# Altium schematic sheet Schematics.SchDoc
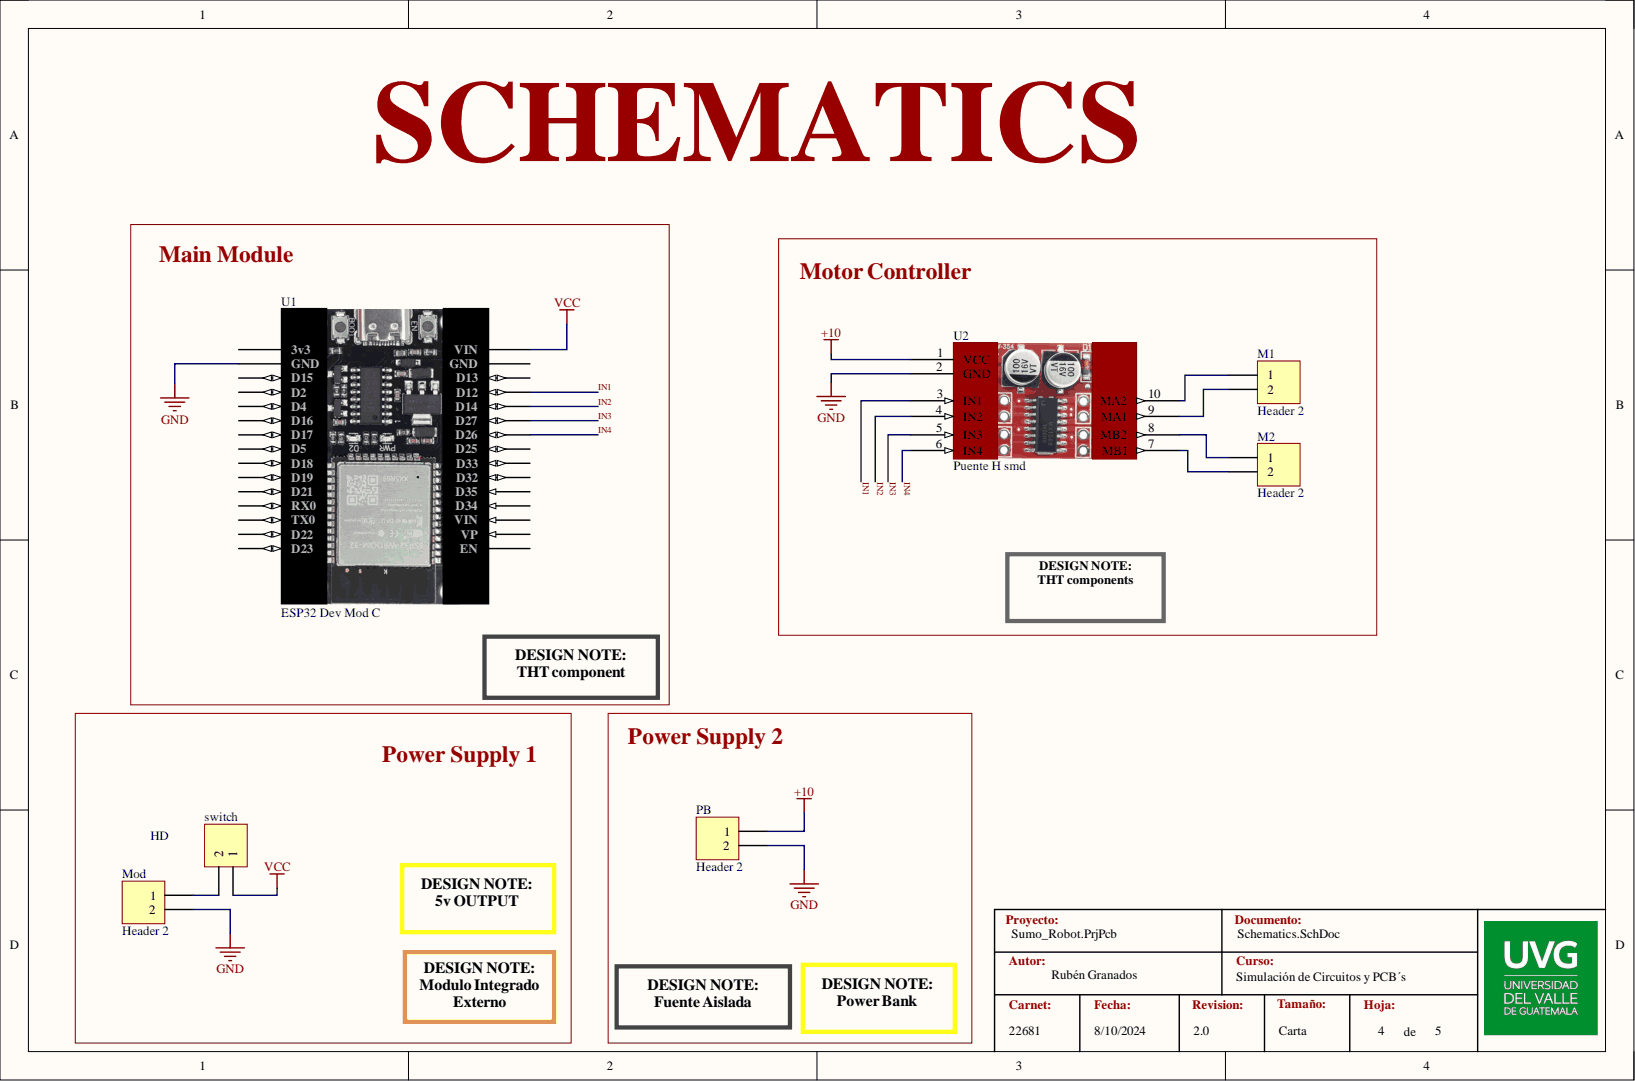
[diagram p0: Schematics.SchDoc]

## Parts
M1  Header 2  [HDR1X2]
M2  Header 2  [HDR1X2]
Mod  Header 2  [HDR1X2]
PB  Header 2  [HDR1X2]
switch  HD  [HDR1X2]
U1  ESP32 Dev Mod C  [ESP32DevModule1]
U2  Puente H smd  [PCBComponent_1]

## Nets
+10: PB.1 U2.1(VCC)
GND: Mod.2 PB.2 U1.2(GND) U2.2(GND)
VCC: switch.1 U1.30(VIN)
IN1: U1.27(D12) U2.3(IN1)
IN2: U1.26(D14) U2.4(IN2)
IN3: U1.25(D27) U2.5(IN3)
IN4: U1.24(D26) U2.6(IN4)
NetM1_1: M1.1 U2.10(MA2)
NetM1_2: M1.2 U2.9(MA1)
NetM2_1: M2.1 U2.8(MB2)
NetM2_2: M2.2 U2.7(MB1)
NetMod_1: Mod.1 switch.2

Not connected: U1 1(3v3) 3(D15) 4(D2) 5(D4) 6(D16) 7(D17) 8(D5) 9(D18) 10(D19) 11(D21) 12(RX0) 13(TX0) 14(D22) 15(D23) 16(EN) 17(VP) 18(VIN) 19(D34) 20(D35) 21(D32) 22(D33) 23(D25) 28(D13) 29(GND)

## Library data of the parts
M1 M2 Mod PB switch = Header 2: Header, 2-Pin; Component Kind: Standard; LatestRevisionDate: 17-Jul-2002; LatestRevisionNote: Re-released for DXP Platform.; Library Name: Miscellaneous Connectors.IntLib; Price: 0.26; Signal Integrity: Connector; Publisher: Altium Limited
U1 = ESP32 Dev C: ESP32 Development Module Type C; Component Kind: Standard; Library Name: Espressif.IntLib; Price: 16.27
U2 = L298N smd: Component Kind: Standard; Library Name: L298N_SMD.IntLib; Price: 2.73
